# Altium schematic sheet 04_Source.SchDoc
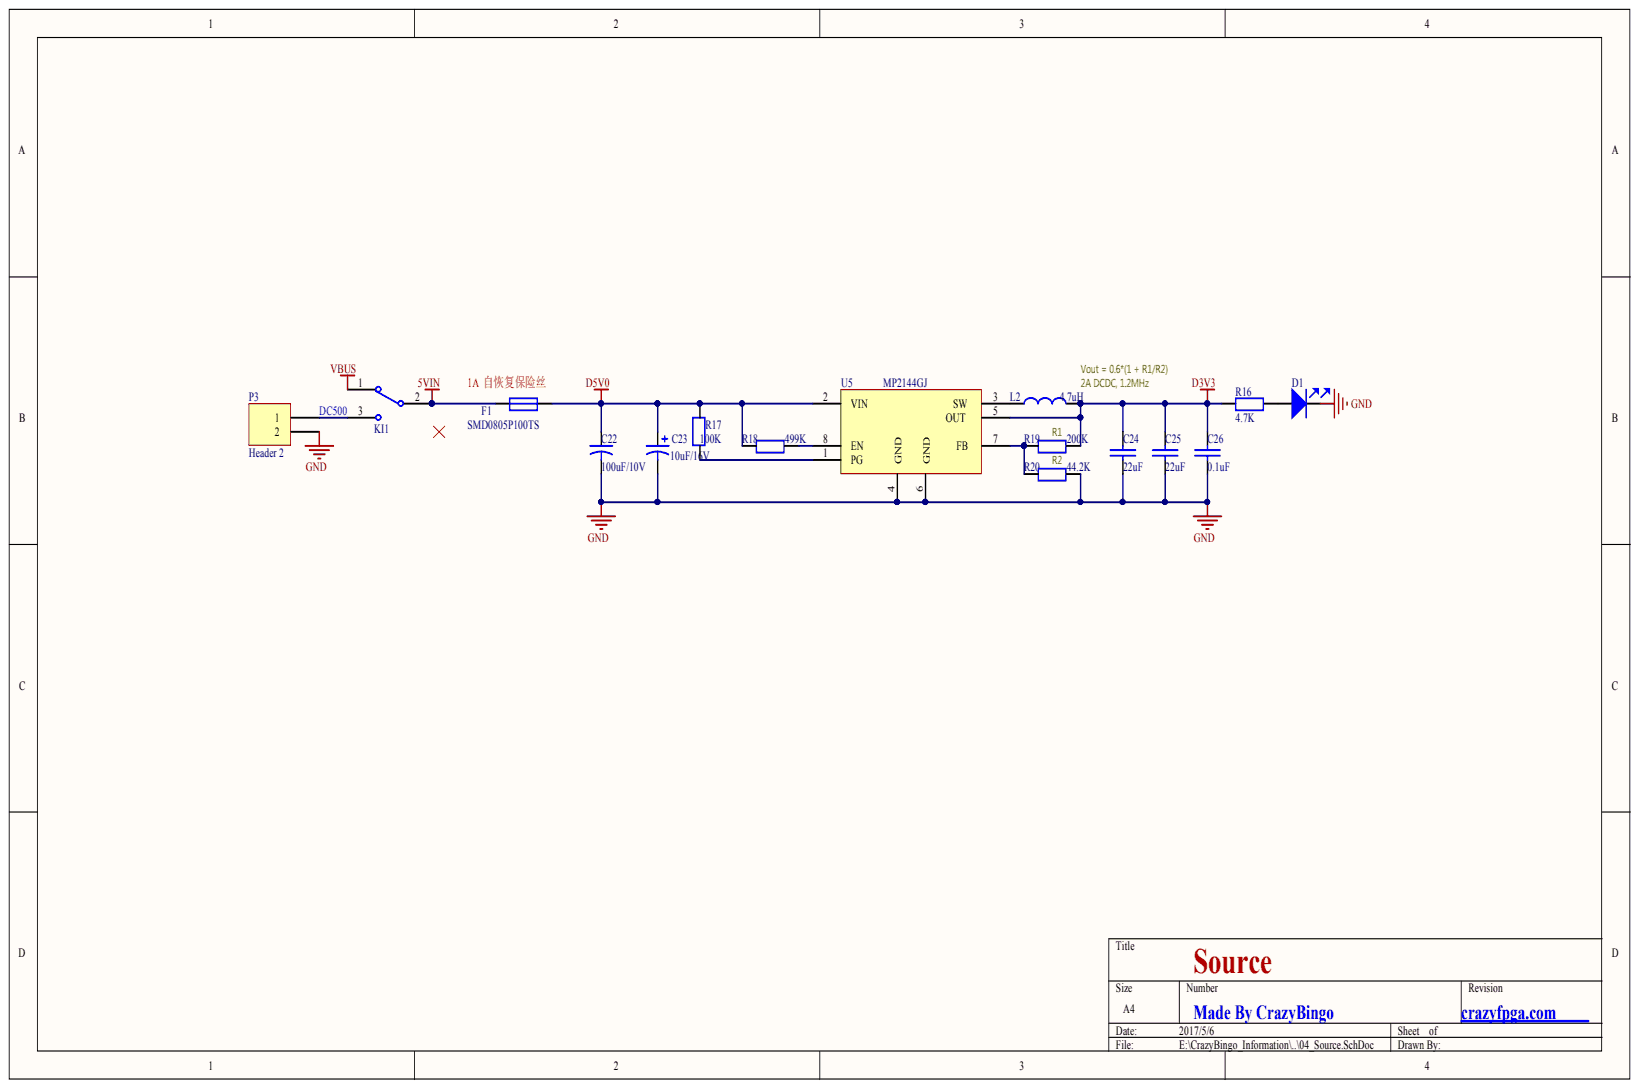
[diagram p0: 04_Source.SchDoc]

## Parts
C22  100uF/10V  [R1210]
C23  10uF/16V  [R0805]
C24  22uF  [R0805]
C25  22uF  [R0805]
C26  0.1uF  [R0603]
D1  LED  [D0603]  (value not drawn)
F1  SMD0805P100TS  [R0805]
KI1  KEY_BO  [KEY_BO]  (value not drawn)
L2  4.7uH  [PRS4018]
P3  Header 2  [HDR1X2]
R16  4.7K  [R0603]
R17  100K  [R0603]
R18  499K  [R0603]
R19  200K  [R0603]
R20  44.2K  [R0603]
U5  MP2144GJ  [SOT23-8]

## Nets
5VIN: F1.1 KI1.2
D3V3: C24.1 C25.1 C26.1 L2.2 R16.1 R19.1 U5.5(OUT)
D5V0: C22.1 C23.1 F1.2 R17.2 R18.2 U5.2(VIN)
GND: C22.2 C23.2 C24.2 C25.2 C26.2 D1.2 P3.2 R20.1 U5.4(GND) U5.6(GND)
VBUS: KI1.1
DC500: KI1.3 P3.1
NetD1_1: D1.1 R16.2
NetL2_1: L2.1 U5.3(SW)
NetR17_1: R17.1 U5.1(PG)
NetR18_1: R18.1 U5.8(EN)
NetR19_2: R19.2 R20.2 U5.7(FB)

## Library data of the parts
C22 = CAP2: Component Kind: Standard; Library Name: Crazy_PCB.SchLib
C23 = Cap Pol1: Polarized Capacitor (Radial); Value: 10uF; Component Kind: Standard; LatestRevisionDate: 17-Jul-2002; LatestRevisionNote: Re-released for DXP Platform.; Library Name: Miscellaneous Devices.IntLib; PackageReference: RB7.6-15; Published: 8-Jun-2000; Signal Integrity: Cap Pol; Simulation: CAP; Publisher: Altium Limited
C24-C26 = CAP: Capacitor; Component Kind: Standard; Library Name: RC522.IntLib
D1 = LED: LED 发光二极管; Component Kind: Standard; Library Name: Crazy_PCB.SchLib
F1 = Fuse: Component Kind: Standard; Description: Fuse; LatestRevisionDate: 17-Jul-2002; LatestRevisionNote: Re-released for DXP Platform.; Library Name: Crazy_PCB.SchLib; PackageReference: PIN-W2/E2.8; Published: 8-Jun-2000; Publisher: Altium Limited
L2 = Inductor: Component Kind: Standard; Library Name: Crazy_PCB.SchLib
P3 = Header 2: Header, 2-Pin; Component Kind: Standard; LatestRevisionDate: 17-Jul-2002; LatestRevisionNote: Re-released for DXP Platform.; Library Name: Miscellaneous Connectors.IntLib; Signal Integrity: Connector; Publisher: Altium Limited
R16-R20 = RES: Component Kind: Standard; Library Name: Crazy_PCB.SchLib
  R17: Vaule: 100K
  R18: Vaule: 499K
  R19: Vaule: 200K
  R20: Vaule: 44.2K
U5 = MP2144GJ: 1.2MHz, DCDC, 2A; Component Kind: Standard; Library Name: Crazy_PCB.SchLib
KI1 = KEY_BO: Component Kind: Standard; Library Name: Crazy_PCB.SchLib
